# Altium schematic sheet SolderIronTemp.SchDoc(SolderIronTemp)
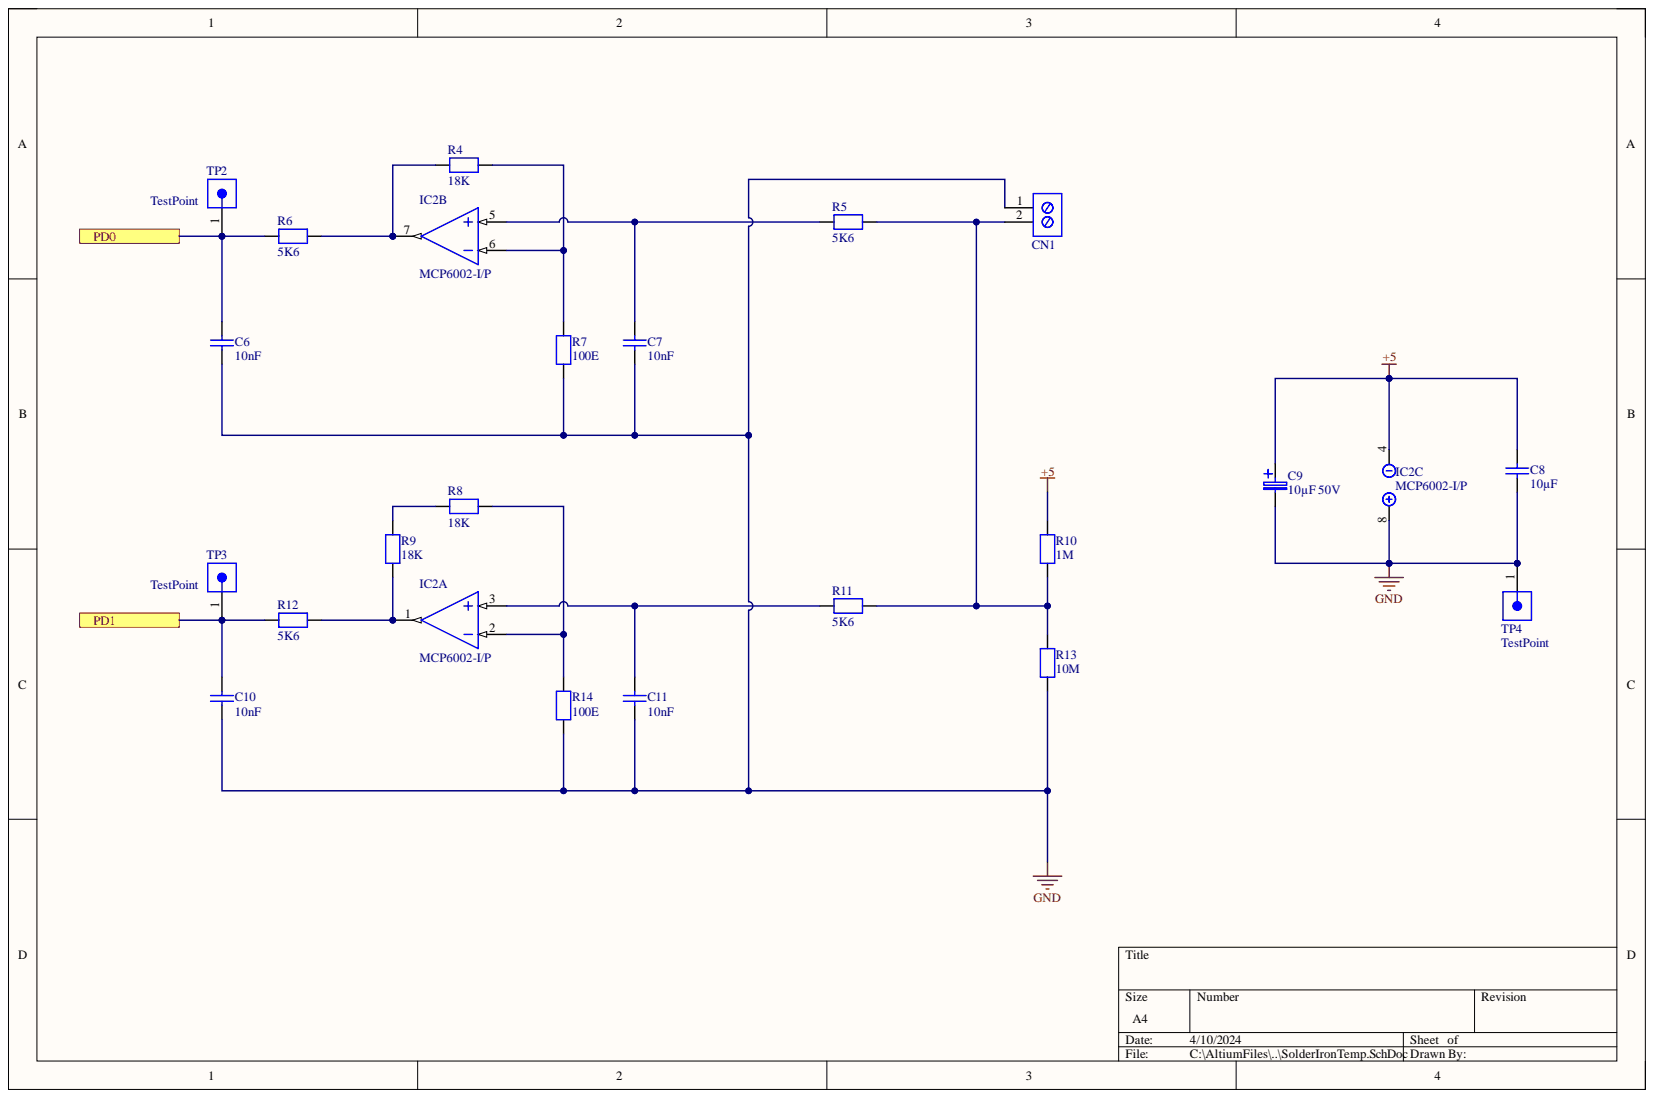
[diagram p0: SolderIronTemp.SchDoc]

## Parts
C6  10nF  [CAPC2013X88X50LL20T25]
C7  10nF  [CAPC2013X88X50LL20T25]
C8  10µF  [CAPC2013X88X50LL20T25]
C9  10µF 50V  [VISH-293D-E_L]
C10  10nF  [CAPC2013X88X50LL20T25]
C11  10nF  [CAPC2013X88X50LL20T25]
CN1  Printkroonsteen 2 POL  [Printkroonsteen 2pin]  (value not drawn)
IC2  MCP6002-I/P  [PDIP300-P8]  (parts A,B,C)
R4  18K  [RESC2013X50X30LL20T20]
R5  5K6  [RESC2013X50X30LL20T20]
R6  5K6  [RESC2013X50X30LL20T20]
R7  100E  [RESC2013X50X30LL20T20]
R8  18K  [RESC2013X50X30LL20T20]
R9  18K  [RESC2013X50X30LL20T20]
R10  1M  [RESC2013X50X30LL20T20]
R11  5K6  [RESC2013X50X30LL20T20]
R12  5K6  [RESC2013X50X30LL20T20]
R13  10M  [RESC2013X50X30LL20T20]
R14  100E  [RESC2013X50X30LL20T20]
TP2  TestPoint  [61300111121]
TP3  TestPoint  [61300111121]
TP4  TestPoint  [61300111121]

## Ports
PD0, PD1

## Nets
+5: C8.2 C9.1 IC2.4 R10.2
GND: C6.1 C7.1 C8.1 C9.2 C10.1 C11.1 CN1.1 IC2.8 R7.1 R13.1 R14.1 TP4.1
PD0: C6.2 R6.1 TP2.1 ; port PD0
PD1: C10.2 R12.1 TP3.1 ; port PD1
NetC7_2: C7.2 IC2.5 R5.1
NetC11_2: C11.2 IC2.3 R11.1
NetCN1_2: CN1.2 R5.2 R10.1 R11.2 R13.2
NetIC2_1: IC2.1 R9.1 R12.2
NetIC2_2: IC2.2 R8.2 R14.2
NetIC2_6: IC2.6 R4.2 R7.2
NetIC2_7: IC2.7 R4.1 R6.2
NetR8_1: R8.1 R9.2

## Library data of the parts
C6-C8 C10 C11 = Capacitor 0805: Manufacturer: Kemet; Part Number: C0402C104K4RACTU; Component Kind: Standard; Library Name: SolderStation.SchLib
C9 = capacitor THT radial tantalum: Tantalum Capacitors - Solid Leaded 50V 10uF 20% ESR=1.6 Ohm TAP106M050BRW; Manufacturer_Name: Kyocera AVX; Geometry.Height: 15.5mm; Component Kind: Standard; Datasheet Link: https://www.mouser.be/datasheet/2/40/tap-776819.pdf; Library Name: SolderStation.SchLib; Manufacturer_Part_Number: TAP106M050BRW; Mouser Part Number: 581-TAP106M050BRW; Mouser Price/Stock: https://www.mouser.co.uk/ProductDetail/AVX/TAP106M050BRW?qs=GjEaAqXp9rZGx11KfVU76g%3D%3D
CN1 = printkroonsteen 1 X 2: Printkroonsteen 2 PO L1716020000; Manufacturer_Name: Weidmuller; Component Kind: Standard; Datasheet Link: https://catalog.weidmueller.com/catalog/Start.do?ObjectID=1716020000&_ga=2.21797499.1716783809.1613378202-511725545.1613378202; Library Name: SolderStation.SchLib; Manufacturer_Part_Number: 1716020000; Mouser Part Number: 470-1716020000; Mouser Price/Stock: https://www.mouser.co.uk/ProductDetail/Weidmuller/1716020000?qs=WJDKaGZ8K%252B7GSEFQgvMjVA%3D%3D
IC2 = CMP-0187-00119-3: Dual opamp; Amplifier Type: GENERAL PURPOSE; Bandwidth: 1MHz; Common Mode Rejection Ratio: 60dB; Input Bias Current: 1pA; Input Offset Voltage (Vos): 4.5mV; Max Frequency: 1kHz; Max Input Voltage: 6V; Min Input Voltage: 1.8V; Nominal Supply Current: 100uA; Number of Amplifiers: 2; Operating Supply Voltage: 5.5V; Output Current: 23mA; Power Supply Rejection Ratio (PSRR): 86dB; Slew Rate: 0.6V/µs; Voltage Gain: 112dB; Case/Package: PDIP; Component Kind: Standard; Gain Bandwidth Product: 1MHz; Height: 0.195inch; Input Offset Voltage-Max: 4.5mV; Lead Free: Lead Free; Length: 0.4inch; Library Name: SolderStation.SchLib; Max Operating Temperature: 85°C; Max Supply Voltage: 6V; Min Operating Temperature: -40°C; Min Supply Voltage: 1.8V; Mount: Through Hole; Number of Channels: 2; Number of Circuits: 2; Number of Elements: 2; Number of Pins: 8; Operating Supply Current: 100uA; Output Current per Channel: 23mA; Package Quantity: 60; Packaging: Rail/Tube; Radiation Hardening: No; REACH SVHC: No SVHC; RoHS Compliant: Yes; Slew Rate-Nom: 0.6V/µs; Supply Current: 100uA; Technology: CMOS; Unity Gain Bandwidth Product: 1MHz; Width: 0.28inch
R4-R14 = resistor 0805: Manufacturer: Vishay Dale; Part Number: CRCW08050000Z0EA; Component Kind: Standard; Library Name: SolderStation.SchLib
TP2-TP4 = TestPoint: NexarPartId: 32855518; Connector Type: Male Pin; Contact Resistance: 20mΩ; Current Rating: 3A; Gender: Male; Number of Contacts: 1; Operating Supply Voltage: 250V; Color: Black; Component Kind: Standard; Contact Material: Copper; Contact Mating Length: 5.9944mm; Contact Plating: Gold; Lead Pitch: 2.54mm; Library Name: SolderStation.SchLib; Mount: Through Hole; Number of Pins: 1; Number of Positions: 1; Number of Rows: 1; Orientation: Vertical; Packaging: Bulk; REACH SVHC: Unknown; RoHS: Compliant; Termination: Solder
